# Altium schematic sheet Schematic Prints("All Documents",Physical)
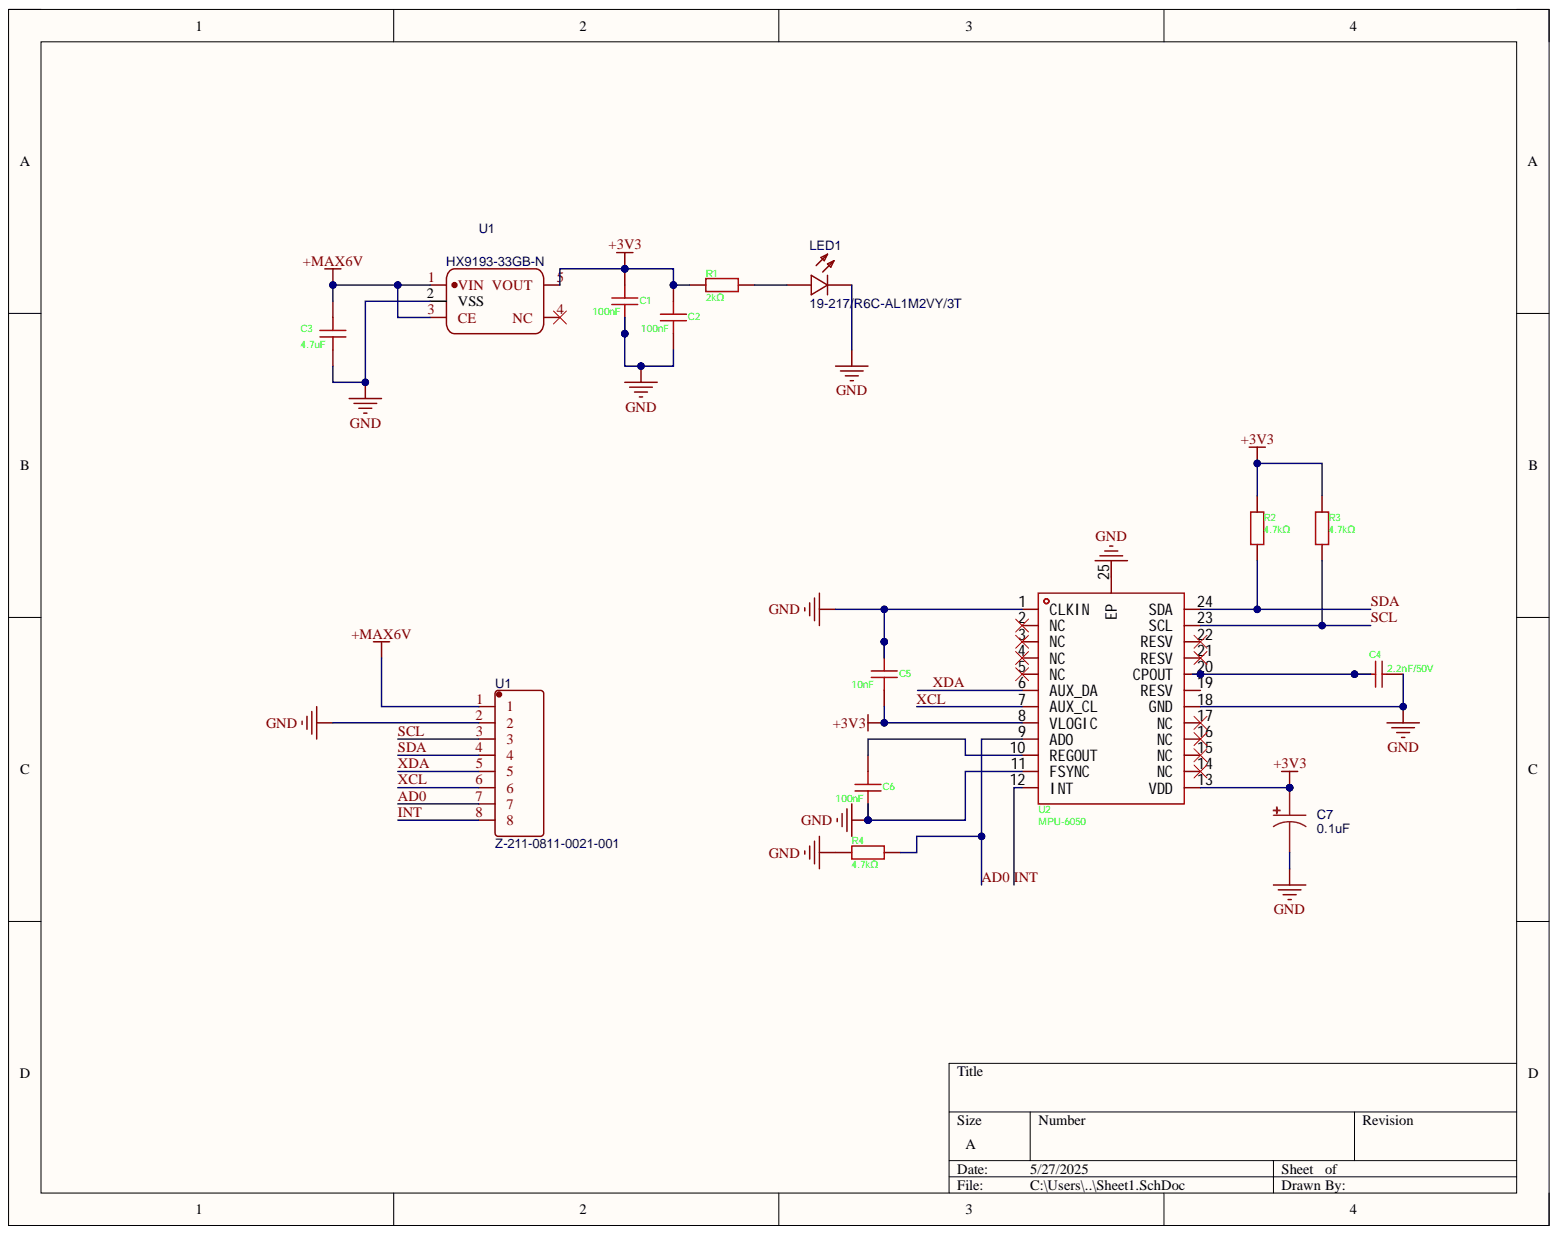
[diagram p0: Schematic Prints("All Documents",Physical)]

## Parts
C1  100nF  [C0603]
C2  100nF  [C0603]
C3  4.7uF  [C0603]
C4  value 2.2nF/50V  [C0805]
C5  10nF  [C0603]
C6  100nF  [C0603]
C7  0.1uF  [CAP-SMD_L3.2-W1.6]
LED1  19-217/R6C-AL1M2VY/3T  [LED0603-RD]
R1  2kΩ  [R0603]
R2  4.7kΩ  [R0603]
R3  4.7kΩ  [R0603]
R4  4.7kΩ  [R0603]
U1
U1  Z-211-0811-0021-001  [HDR-TH_8P-P2.54-V-M]
U2  MPU-6050  [QFN-24_L4.0-W4.0-P0.50-BL-EP2.7]

## Nets
+3V3: C1.2 C2.2 C5.1 C7.1 R1.1 R2.2 R3.2 U1.5 U2.8(VLOGIC) U2.13(VDD)
+MAX6V: C3.2 U1.1 U1.3
GND: C1.1 C2.1 C3.1 C4.2 C5.2 C6.1 C7.2 LED1.1 R4.2 U1.2 U2.1(CLKIN) U2.11(FSYNC) U2.18(GND) U2.25(EP)
AD0: R4.1 U1.7 U2.9(AD0)
INT: U1.8 U2.12(INT)
SCL: R3.1 U1.3 U2.23(SCL)
SDA: R2.1 U1.4 U2.24(SDA)
XCL: U1.6 U2.7(AUX_CL)
XDA: U1.5 U2.6(AUX_DA)
NetC4_1: C4.1 U2.20(CPOUT)
NetC6_2: C6.2 U2.10(REGOUT)
NetLED1_2: LED1.2 R1.2
NetU1_4: U1.4
NetU2_2: U2.2(NC)
NetU2_3: U2.3(NC)
NetU2_4: U2.4(NC)
NetU2_5: U2.5(NC)
NetU2_14: U2.14(NC)
NetU2_15: U2.15(NC)
NetU2_16: U2.16(NC)
NetU2_17: U2.17(NC)
NetU2_19: U2.19(RESV)
NetU2_21: U2.21(RESV)
NetU2_22: U2.22(RESV)

## Library data of the parts
C1 C2 C6 = CC0603KRX7R9BB104: Manufacturer: YAGEO(国巨); Add into BOM: yes; Tolerance: ±10%; Component Kind: Standard; Convert to PCB: yes; Datasheet: https://atta.szlcsc.com/upload/public/pdf/source/20180910/C282072_33301C7F631E79921B82A8DAC6BCC5B3.pdf; Device: CC0603KRX7R9BB104; JLCPCB Part Class: Basic Part; LCSC Part Name: 100nF ±10% 50V; Library Name: Schlib1.SchLib; Manufacturer Part: CC0603KRX7R9BB104; PCB3D: C0603_L1.6-W0.8-H0.8; Supplier: LCSC; Supplier Footprint: 0603; Supplier Part: C14663; Symbol: CC0603KRX7R9BB104; Value: 100nF
C3 = CL10A475KO8NNNC: Manufacturer: SAMSUNG(三星); Add into BOM: yes; Tolerance: ±10%; Component Kind: Standard; Convert to PCB: yes; Datasheet: https://atta.szlcsc.com/upload/public/pdf/source/20160218/1457707763339.pdf; Device: CL10A475KO8NNNC; JLCPCB Part Class: Basic Part; LCSC Part Name: 4.7uF ±10% 16V; Library Name: Schlib1.SchLib; Manufacturer Part: CL10A475KO8NNNC; PCB3D: C0603_L1.6-W0.8-H0.8; Supplier: LCSC; Supplier Footprint: 0603; Supplier Part: C19666; Symbol: CL10A475KO8NNNC; Value: 4.7uF
C4 = CL21C222JBFNNNE: Manufacturer: SAMSUNG(三星); Add into BOM: yes; Tolerance: ±5%; Component Kind: Standard; Convert to PCB: yes; Datasheet: https://atta.szlcsc.com/upload/public/pdf/source/20160218/1457707763339.pdf; Device: CL21C222JBFNNNE; JLCPCB Part Class: Basic Part; LCSC Part Name: 2.2nF ±5% 50V; Library Name: Schlib1.SchLib; Manufacturer Part: CL21C222JBFNNNE; PCB3D: C0805_L2.0-W1.3-H1.3; Supplier: LCSC; Supplier Footprint: 0805; Supplier Part: C28260; Symbol: CL21C222JBFNNNE
C5 = CC0603KRX7R9BB104: Manufacturer: YAGEO(国巨); Add into BOM: yes; Tolerance: ±10%; Value: 100nF; Component Kind: Standard; Convert to PCB: yes; Datasheet: https://atta.szlcsc.com/upload/public/pdf/source/20180910/C282072_33301C7F631E79921B82A8DAC6BCC5B3.pdf; Device: CC0603KRX7R9BB104; JLCPCB Part Class: Basic Part; LCSC Part Name: 100nF ±10% 50V; Library Name: Schlib1.SchLib; Manufacturer Part: CC0603KRX7R9BB104; PCB3D: C0603_L1.6-W0.8-H0.8; Supplier: LCSC; Supplier Footprint: 0603; Supplier Part: C14663; Symbol: CC0603KRX7R9BB104
C7 = 10uF: Manufacturer: Kyocera AVX; Manufacturer Part: TPSA106K016R1000; Contributor: LCSC; nameAlias: Value; Component Kind: Standard; JLCPCB Part Class: Extended Part; Library Name: Schlib1.SchLib; spicePre: C; spiceSymbolName: TPSA106K016R1000_C282116; Supplier: LCSC; Supplier Part: C282116
LED1 = 19-217/R6C-AL1M2VY/3T: Manufacturer: EVERLIGHT(亿光); Component Kind: Standard; JLCPCB Part Class: Extended Part; Library Name: Schlib1.SchLib; Manufacturer Part: 19-217/R6C-AL1M2VY/3T; spicePre: L; spiceSymbolName: 19-217/R6C-AL1M2VY/3T; Supplier: LCSC; Supplier Part: C72044
R1 = RC0603FR-072KL: Manufacturer: YAGEO(国巨); Add into BOM: yes; Power(Watts): 100mW; Temperature Coefficient: ±100ppm/℃; Tolerance: ±1%; Voltage-Supply(Max): 75V; Component Kind: Standard; Convert to PCB: yes; Datasheet: https://atta.szlcsc.com/upload/public/pdf/source/20180316/70C0E2BDAE7FCC62C531A5A58D202EE1.pdf; Device: RC0603FR-072KL; JLCPCB Part Class: Extended Part; LCSC Part Name: 厚膜电阻 2kΩ ±1% 100mW; Library Name: Schlib1.SchLib; Manufacturer Part: RC0603FR-072KL; Operating Temperature: -55℃~+155℃; PCB3D: R0603; Supplier: LCSC; Supplier Footprint: 0603; Supplier Part: C105576; Symbol: RC0603FR-072KL; Type: Thick Film Resistors; Value: 2kΩ
R2-R4 = RC0603FR-074K7L: Manufacturer: YAGEO(国巨); Add into BOM: yes; Temperature Coefficient: ±100ppm/℃; Tolerance: ±1%; Component Kind: Standard; Convert to PCB: yes; Datasheet: https://atta.szlcsc.com/upload/public/pdf/source/20180224/C181757_15194369480721363983.pdf; Device: RC0603FR-074K7L; JLCPCB Part Class: Extended Part; LCSC Part Name: 厚膜电阻 4.7kΩ ±1% 100mW; Library Name: Schlib1.SchLib; Manufacturer Part: RC0603FR-074K7L; Operating Temperature Range: -55℃~+155℃; PCB3D: R0603; Supplier: LCSC; Supplier Footprint: 0603; Supplier Part: C99782; Symbol: RC0603FR-074K7L; Type: Thick Film Resistors; Value: 4.7kΩ
U1 = Z-211-0811-0021-001: Manufacturer: Nextron(正凌); Contributor: LCSC; Component Kind: Standard; JLCPCB Part Class: Extended Part; Library Name: Schlib1.SchLib; Manufacturer Part: Z-211-0811-0021-001; spicePre: U; spiceSymbolName: Z-211-0811-0021-001; Supplier: LCSC; Supplier Part: C190820
U2 = MPU-6050: Manufacturer: TDK InvenSense(应美盛); Add into BOM: yes; Sensor Type: 加速度计陀螺仪; Symbol: MPU-6050_C24112; Component Kind: Standard; Convert to PCB: yes; Datasheet: https://atta.szlcsc.com/upload/public/pdf/source/20140425/1457707046159.pdf; Device: MPU-6050; JLCPCB Part Class: Extended Part; LCSC Part Name: MPU-6050; Library Name: Schlib1.SchLib; Manufacturer Part: MPU-6050; Operating Temperature: -40℃~+85℃; PCB3D: QFN-24_L4.0-W4.0-P0.50-BL-EP2.7; Supplier: LCSC; Supplier Footprint: QFN-24-EP(4x4); Supplier Part: C24112
